# Altium schematic sheet schematic.SchDoc(schematic)
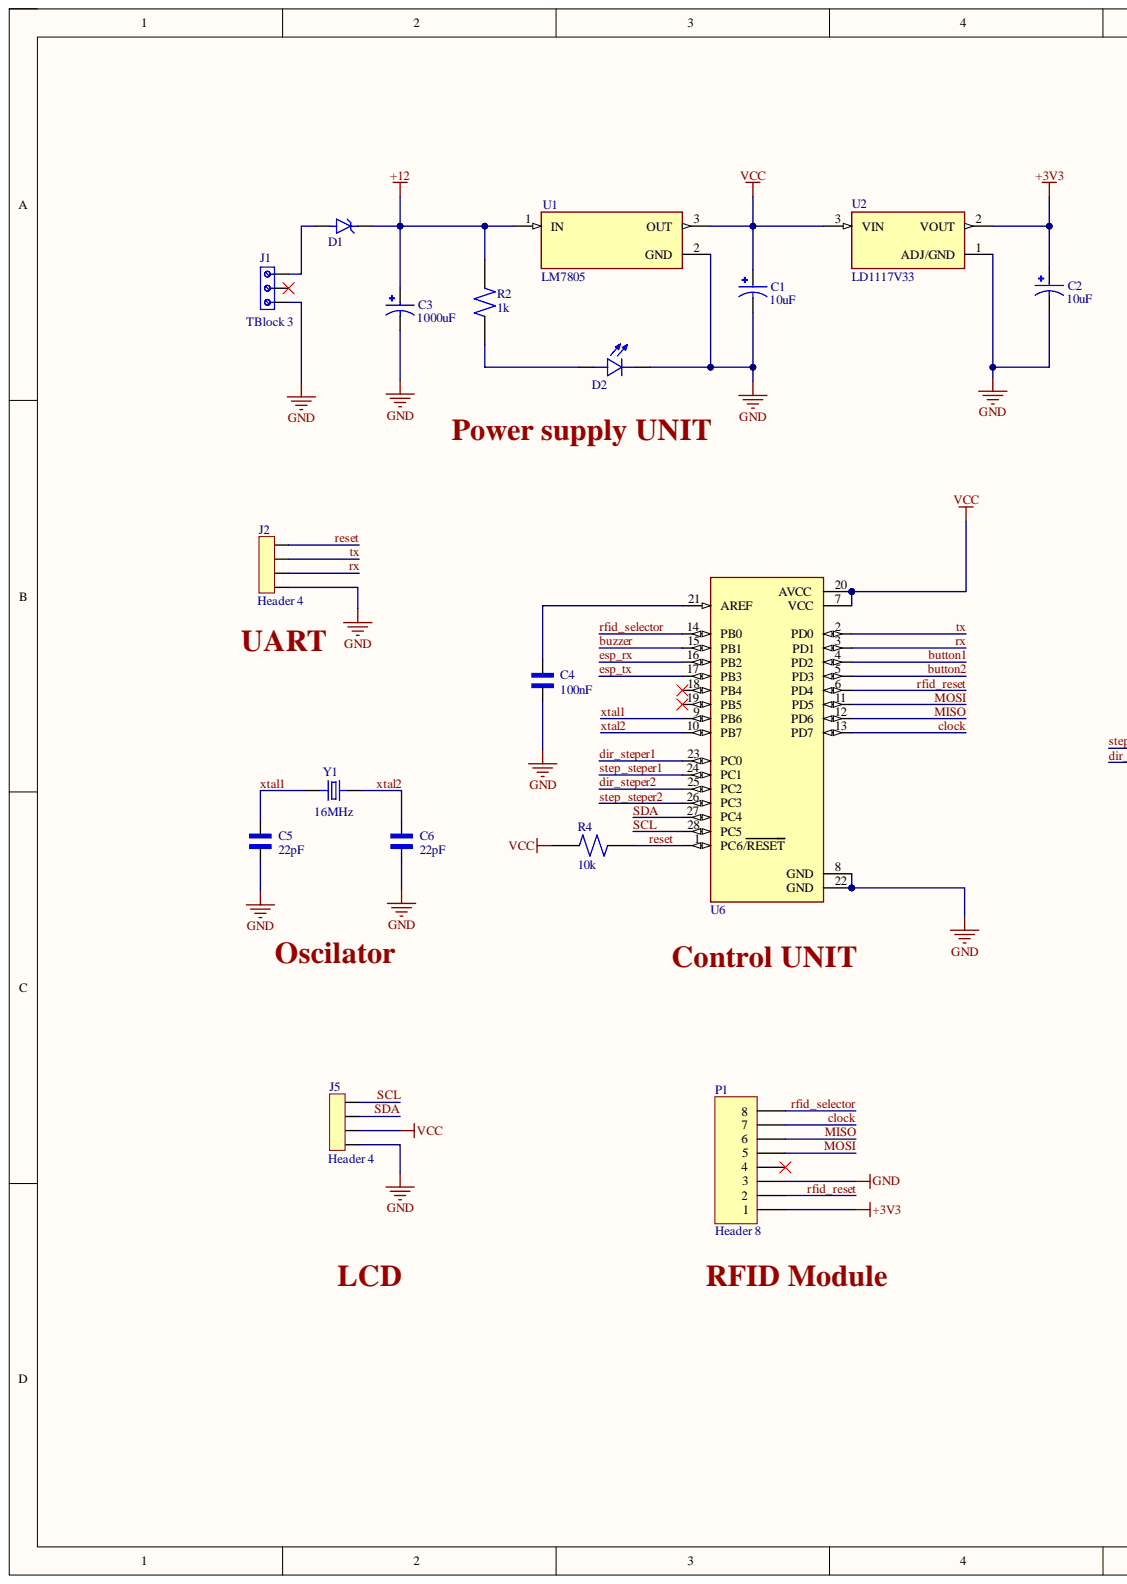
[diagram p0: schematic.SchDoc, left]
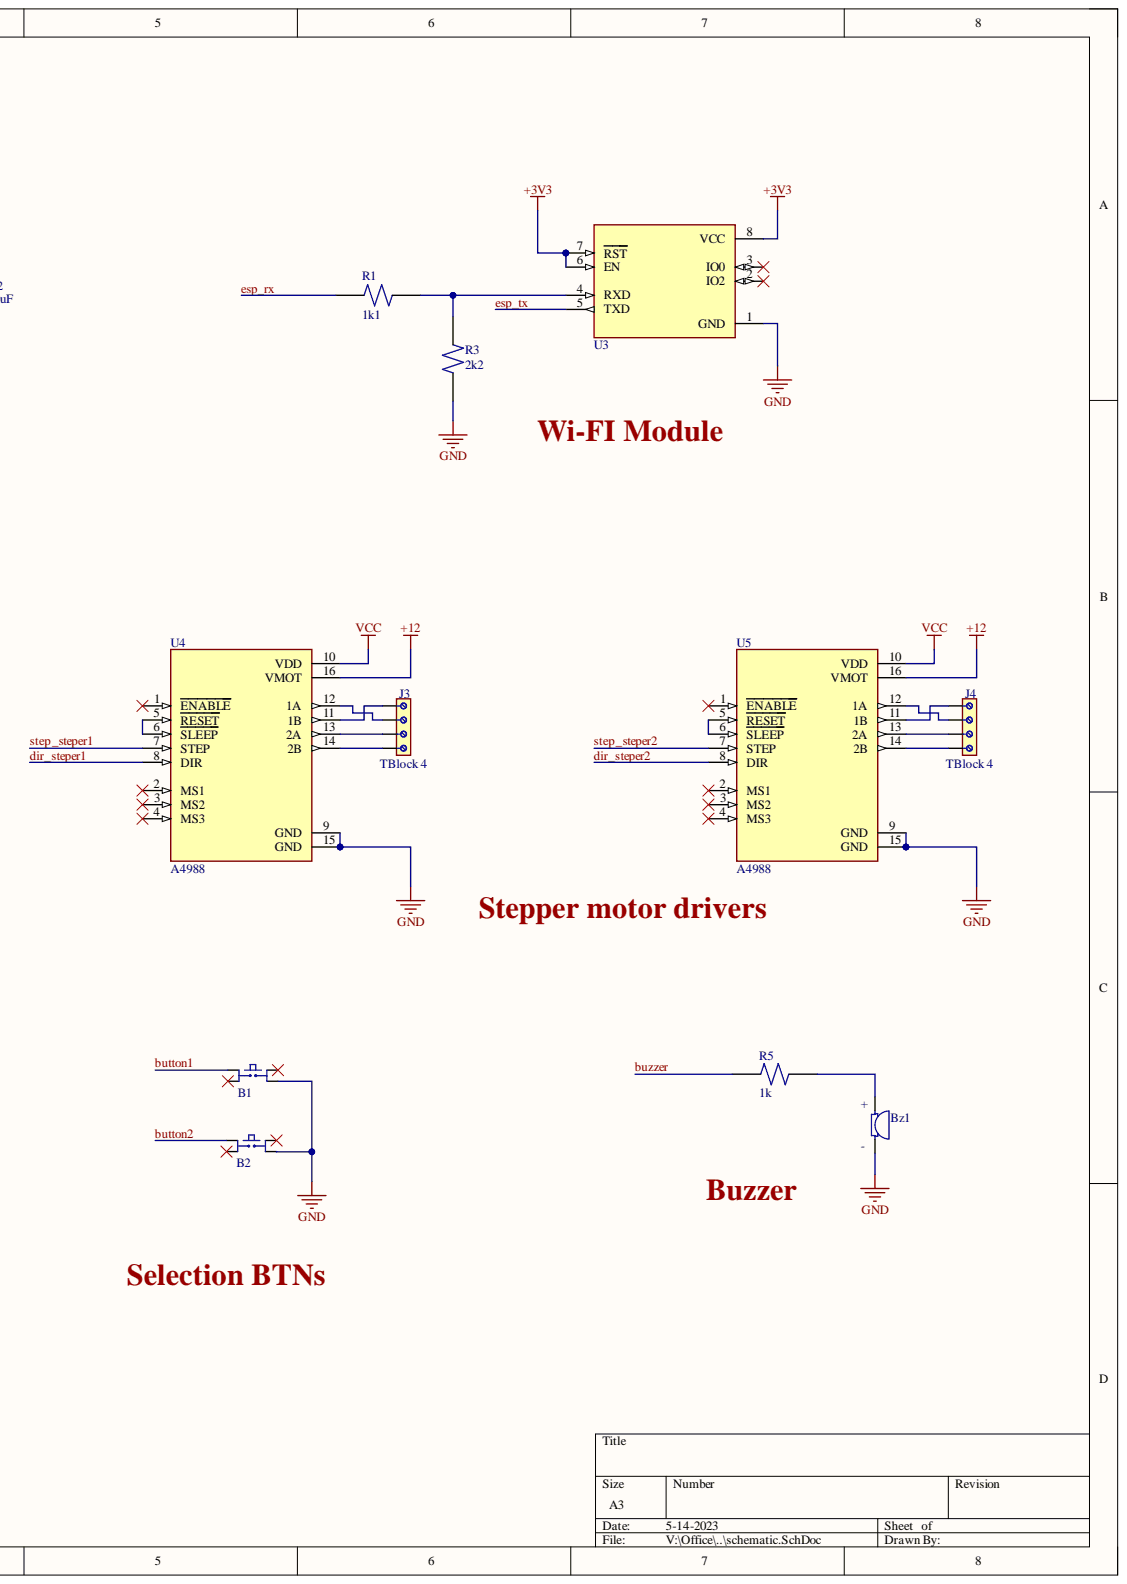
[diagram p1: schematic.SchDoc, right]

## Parts
B1  Push button  [SW_TS02-66-95-BK-260-LCR-D]  (value not drawn)
B2  Push button  [SW_TS02-66-95-BK-260-LCR-D]  (value not drawn)
Bz1  AI-1223-TWT-12V-R  [XDCR_AI-1223-TWT-12V-R]  (value not drawn)
C1  10uF  [CAPPRD500W50D500H1100]
C2  10uF  [CAPPRD500W50D500H1100]
C3  1000uF  [CAPPRD500W50D500H1100]
C4  100nF  [CAPRB500W50L450T300H550]
C5  22pF  [CAPRB500W50L450T300H550]
C6  22pF  [CAPRB500W50L450T300H550]
D1  1N4745A  [DIOAD1060W70L435D230]  (value not drawn)
D2  L08R5000Q1  [LEDRD254W57D500H1070]  (value not drawn)
J1  TBlock 3  [691214110003]
J2  Header 4  [SULLINS_PPTC041LFBN-RC]
J3  TBlock 4  [691241510004]
J4  TBlock 4  [691241510004]
J5  Header 4  [SULLINS_PPTC041LFBN-RC]
P1  Header 8  [HDR1X8]
R1  1k1  [RESAD1100W55L680D260]
R2  1k  [RESAD1100W55L680D260]
R3  2k2  [RESAD1100W55L680D260]
R4  10k  [RESAD1100W55L680D260]
R5  1k  [RESAD1100W55L680D260]
U1  LM7805  [TO254P1054X470X1955-3]
U2  LD1117V33  [TO255P1020X450X1968-3]
U3  ESP8266-01/ESP-01  [XCVR_ESP8266-01/ESP-01]  (value not drawn)
U4  A4988  [MODULE_A4988_STEPPER_MOTOR_DRIVER_CARRIER]
U5  A4988  [MODULE_A4988_STEPPER_MOTOR_DRIVER_CARRIER]
U6  ATMEGA328P-PU  [DIP794W46P254L2967H457Q28B]  (value not drawn)
Y1  16MHz  [XTAL_ECS-160-20-4X]

## Nets
+3V3: C2.P P1.1 U2.2 U3.6(EN) U3.7(RST) U3.8(VCC)
+12: C3.P D1.C R2.2 U1.1 U4.16(VMOT) U5.16(VMOT)
GND: B1.4 B2.4 Bz1.N C1.N C2.N C3.N C4.1 C5.1 C6.1 D2.C J1.1 J2.4 J5.4 P1.3 R3.1 U1.2 U2.1 U3.1(GND) U4.9(GND) U4.15(GND) U5.9(GND) U5.15(GND) U6.8(GND) U6.22(GND)
VCC: C1.P J5.3 R4.1 U1.3 U2.3 U4.10(VDD) U5.10(VDD) U6.7(VCC) U6.20(AVCC)
button1: B1.1 U6.4(PD2)
button2: B2.1 U6.5(PD3)
buzzer: R5.2 U6.15(PB1)
clock: P1.7 U6.13(PD7)
dir_steper1: U4.8(DIR) U6.23(PC0)
dir_steper2: U5.8(DIR) U6.25(PC2)
esp_rx: R1.2 U6.16(PB2)
esp_tx: U3.5(TXD) U6.17(PB3)
MISO: P1.6 U6.12(PD6)
MOSI: P1.5 U6.11(PD5)
reset: J2.1 R4.2 U6.1(PC6/RESET)
rfid_reset: P1.2 U6.6(PD4)
rfid_selector: P1.8 U6.14(PB0)
rx: J2.3 U6.3(PD1)
SCL: J5.1 U6.28(PC5)
SDA: J5.2 U6.27(PC4)
step_steper1: U4.7(STEP) U6.24(PC1)
step_steper2: U5.7(STEP) U6.26(PC3)
tx: J2.2 U6.2(PD0)
xtal1: C5.2 U6.9(PB6) Y1.2
xtal2: C6.2 U6.10(PB7) Y1.1
NetBz1_P: Bz1.P R5.1
NetC4_2: C4.2 U6.21(AREF)
NetD1_A: D1.A J1.3
NetD2_A: D2.A R2.1
NetJ3_1: J3.1 U4.11(1B)
NetJ3_2: J3.2 U4.12(1A)
NetJ3_3: J3.3 U4.13(2A)
NetJ3_4: J3.4 U4.14(2B)
NetJ4_1: J4.1 U5.11(1B)
NetJ4_2: J4.2 U5.12(1A)
NetJ4_3: J4.3 U5.13(2A)
NetJ4_4: J4.4 U5.14(2B)
NetR1_1: R1.1 R3.2 U3.4(RXD)
NetU4_5: U4.5(RESET) U4.6(SLEEP)
NetU5_5: U5.5(RESET) U5.6(SLEEP)

Not connected: B1 2 3; B2 2 3; J1 2; P1 4; U3 2(IO2) 3(IO0); U4 1(ENABLE) 2(MS1) 3(MS2) 4(MS3); U5 1(ENABLE) 2(MS1) 3(MS2) 4(MS3); U6 18(PB4) 19(PB5)

## Library data of the parts
Bz1 = Buzzer: ELECTRO-MECHANICAL AUDIO INDICATOR; MF: PUI Audio,; Availability: In Stock; Component Kind: Standard; MP: AI-1223-TWT-12V-R; Package: 2225 PUI Audio; Price: None; Purchase-URL: https://pricing.snapeda.com/search/part/AI-1223-TWT-12V-R/?ref=eda; Library Name: Installed library.IntLib
C1-C3 = Electrolytic capacitor: FS-A; MF: {'manufacturer': u'Panasonic'}; MP: EEUFS0J221B; Availability: Unavailable; Component Kind: Standard; Package: None; Price: None; Library Name: Installed library.IntLib
C4-C6 = Ceramic capacitor: 2200 pF Â±10% 250V Ceramic Capacitor X7R Radial; MF: TDK; MP: FG24X7R2E222KNT06; Check_prices: https://www.snapeda.com/parts/FG24X7R2E222KNT06/TDK/view-part/?ref=eda; Component Kind: Standard; Digi-Key_Part_Number: 445-173386-1-ND; Package: Radial TDK; Purchase-URL: https://pricing.snapeda.com/search/part/FG24X7R2E222KNT06/?ref=eda; SnapEDA_Link: https://www.snapeda.com/parts/FG24X7R2E222KNT06/TDK/view-part/?ref=snap; Library Name: Installed library.IntLib
D1 = Zenner diode: Zener Diode 16V 1W Â±5% Through Hole DO-204AL (DO-41); MF: ON Semiconductor; Availability: In Stock; Check_prices: https://www.snapeda.com/parts/1N4745A/Onsemi/view-part/?ref=eda; Component Kind: Standard; MP: 1N4745A; Package: DO-41-2 ON Semiconductor; Price: None; Purchase-URL: https://pricing.snapeda.com/search/part/1N4745A/?ref=eda; SnapEDA_Link: https://www.snapeda.com/parts/1N4745A/Onsemi/view-part/?ref=snap; Library Name: Installed library.IntLib
D2 = LED: LED, Orange, Through Hole, T-1 3/4 (5mm), 30 mA, 2.1 V, 635 nm; MF: {'manufacturer': u'LED Technology'}; Availability: Unavailable; Component Kind: Standard; MP: L08R5000Q1; Package: None; Price: None; Library Name: Installed library.IntLib
J1 = Terminal blocks - 3Pins (Small): 3 Position Wire to Board Terminal Block Horizontal with Board 0.138 (3.50mm) Through Hole; MF: Wurth Elektronik; Availability: In Stock; Check_prices: https://www.snapeda.com/parts/691214110003/Wurth+Elektronik/view-part/?ref=eda; Component Kind: Standard; MP: 691214110003; Package: None; Price: None; Purchase-URL: https://pricing.snapeda.com/search/part/691214110003/?ref=eda; SnapEDA_Link: https://www.snapeda.com/parts/691214110003/Wurth+Elektronik/view-part/?ref=snap; Library Name: Installed library.IntLib
J2 J5 = Female header - 4Pins: 4 Position Header Connector Through Hole; MF: Sullins Connector; MP: PPTC041LFBN-RC; Availability: Warning; Check_prices: https://www.snapeda.com/parts/PPTC041LFBN-RC/Sullins%20Connector%20Solutions/view-part/285917/?ref=eda; Component Kind: Standard; Package: None; Price: None; Purchase-URL: https://pricing.snapeda.com/search/part/PPTC041LFBN-RC/?ref=eda; SnapEDA_Link: https://www.snapeda.com/parts/PPTC041LFBN-RC/Sullins%20Connector%20Solutions/view-part/285917/?ref=snap; Library Name: Installed library.IntLib
J3 J4 = Terminal block - 4Pins: <b>WR-TBL Serie 2415 - 5.08 mm Horizontal Entry Modular w. Rising Cage Clamp</b> <br> <br><b> KIND PROPERTIES </b> <br><br> Pitch 5.08 mm  <br> <br> <b>MATERIAL PROPERTIES </b> <br> <br>Insulator Material PA66  <br>Insulator Flammability Rating UL94 V-0  <br>Terminal Screw Material Steel  <br>Terminal Screw Plating Zinc  <br>Wire Guard Material Copper Alloy  <br> <br> <b>GENERAL INFORMATION </b> <br> <br>Operating Temperature -30 up to +120 Â°C  <br>Compliance ROHS & Reach  <br><br> <b>ELECTRICAL PROPERTIES </b> <br> <br>IR UL 14 A  <br>IR VDE 24 A  <br>Working Voltage [UL] 300 V (AC)  <br>Working Voltage [VDE] 250 V (AC)  <br>Withstanding Voltage [UL] 1600 V (AC)  <br>Withstanding Voltage [VDE] 2000 V (AC)  <br>Contact Resistance 20 mÎ©  <br> <br> <b>MECHANICAL PROPERTIES </b> <br> <br>Wire Strip Length 6  <br>Screw M3  <br> <br> <b>CERTIFICATION </b> <br> <br>UL Approval E150931  <br>VDE Approval 40021365  <br> <br> <b>PACKAGING PROPERTIES </b> <br> <br>Packaging Box  <br> <br> <br><a href="https://www.we-online.com/catalog/media/o79416v209%20Family_WR-TBL_6912427000xx_6912425000xx.jpg" title="Enlarge picture"> <img src="https://www.we-online.com/catalog/media/o79416v209%20Family_WR-TBL_6912427000xx_6912425000xx.jpg" width="320"></a><p> Details see: <a href="https://www.we-online.com/catalog/en/TBL_5_08_2415_HORIZONTAL_ENTRY_W_RISING_CAGE_6912415100xx/">https://www.we-online.com/catalog/en/TBL_5_08_2415_HORIZONTAL_ENTRY_W_RISING_CAGE_6912415100xx/</a><p>  </b>Updated by Ella Wu  2019-11-26<br> </b>2019(C) Wurth Elektronik; MF: WÃ¼rth Elektronik; Availability: In Stock; Check_prices: https://www.snapeda.com/parts/691241510004/Wurth+Electronics+Inc./view-part/?ref=eda; Component Kind: Standard; MP: 691241510004; Package: None; Price: None; Purchase-URL: https://pricing.snapeda.com/search/part/691241510004/?ref=eda; SnapEDA_Link: https://www.snapeda.com/parts/691241510004/Wurth+Electronics+Inc./view-part/?ref=snap; Library Name: Installed library.IntLib
P1 = Header 8: Header, 8-Pin; Component Kind: Standard; LatestRevisionDate: 17-Jul-2002; LatestRevisionNote: Re-released for DXP Platform.; Library Name: Miscellaneous Connectors.IntLib; Signal Integrity: Connector; Publisher: Altium Limited
R1-R5 = Resistor (0.25W): (MFR-25FBF52-10K) 10,2 kOhm bei 1% 0,25W, 1/4W Durchbohrlochwiderstand Axial Metal Film; MF: Yageo; Component Kind: Standard; Digi-Key_Part_Number: 10.2KXBK-ND; MP: MFR-25FBF52-10K; Package: Axial Yageo; Purchase-URL: https://pricing.snapeda.com/search/part/MFR-25FBF52-10K/?ref=eda; SnapEDA_Link: https://www.snapeda.com/parts/MFR-25FBF52-10K/Yageo/view-part/424333/?ref=snap; Library Name: Installed library.IntLib
U1 = LM7805: MP: LM7805CT/NOPB; Availability: Unavailable; Component Kind: Standard; Package: None; Price: None; Library Name: Installed library.IntLib
U3 = ESP8266-01 Module: MakerFocus 4pcs ESP8266 ESP-01 Serial Wireless WiFi Transceiver Receiver Module 1MB SPI Flash DC3.0-3.6V Internet of Things WiFi Module Board Compatible with Ar duino; Availability: Unavailable; Component Kind: Standard; MP: ESP8266-01/ESP-01; Package: None; Price: None; Library Name: Installed library.IntLib
U4 U5 = A4988_STEPPER_MOTOR_DRIVER_CARRIER: Stepper motor controler; IC: A4988; 1A; Uin mot: 8Ã·35V; Availability: Unavailable; Component Kind: Standard; Library Name: A4988_STEPPER_MOTOR_DRIVER_CARRIER.SchLib; MP: A4988_STEPPER_MOTOR_DRIVER_CARRIER; Package: None; Price: None
U6 = ATMEGA328P-PU: ATmega Series 20 MHz 32 KB Flash 2 KB SRAM 8-Bit Microcontroller - DIP-28; Availability: Unavailable; Component Kind: Standard; MP: ATMEGA328P-PU; Package: None; Price: None; Library Name: Installed library.IntLib
Y1 = Crystal oscilator: CRYSTAL T/H; MF: {'manufacturer': u'ECS Inc.'}; MP: ECS-160-S-4; Availability: Unavailable; Component Kind: Standard; Package: None; Price: None; Library Name: Installed library.IntLib
B1 B2 = Push button: Component Kind: Standard; Library Name: Installed library.IntLib
U2 = LD1117V33: Availability: Unavailable; Component Kind: Standard; MP: LD1117V33; Package: None; Price: None; Library Name: Installed library.IntLib
